# Altium schematic sheet Connector_0.SchDoc(Connector_0)
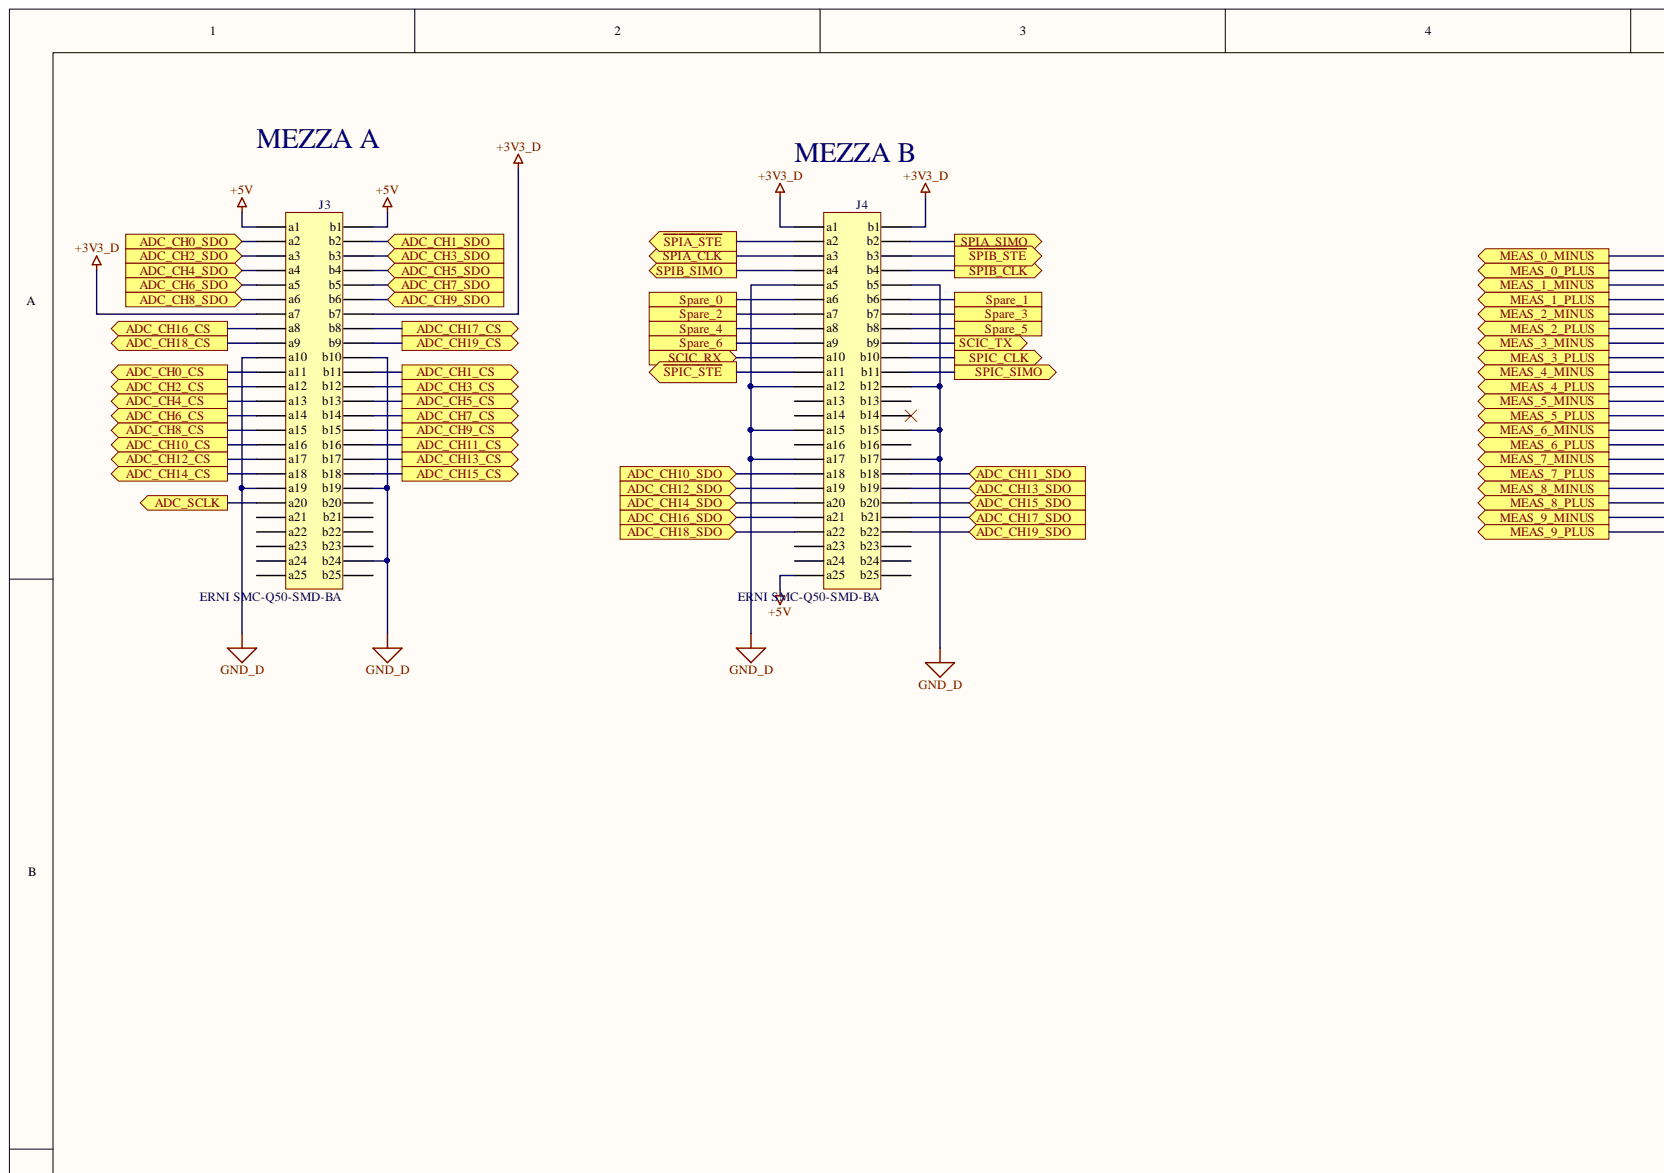
[diagram p0: Connector_0.SchDoc, top left]
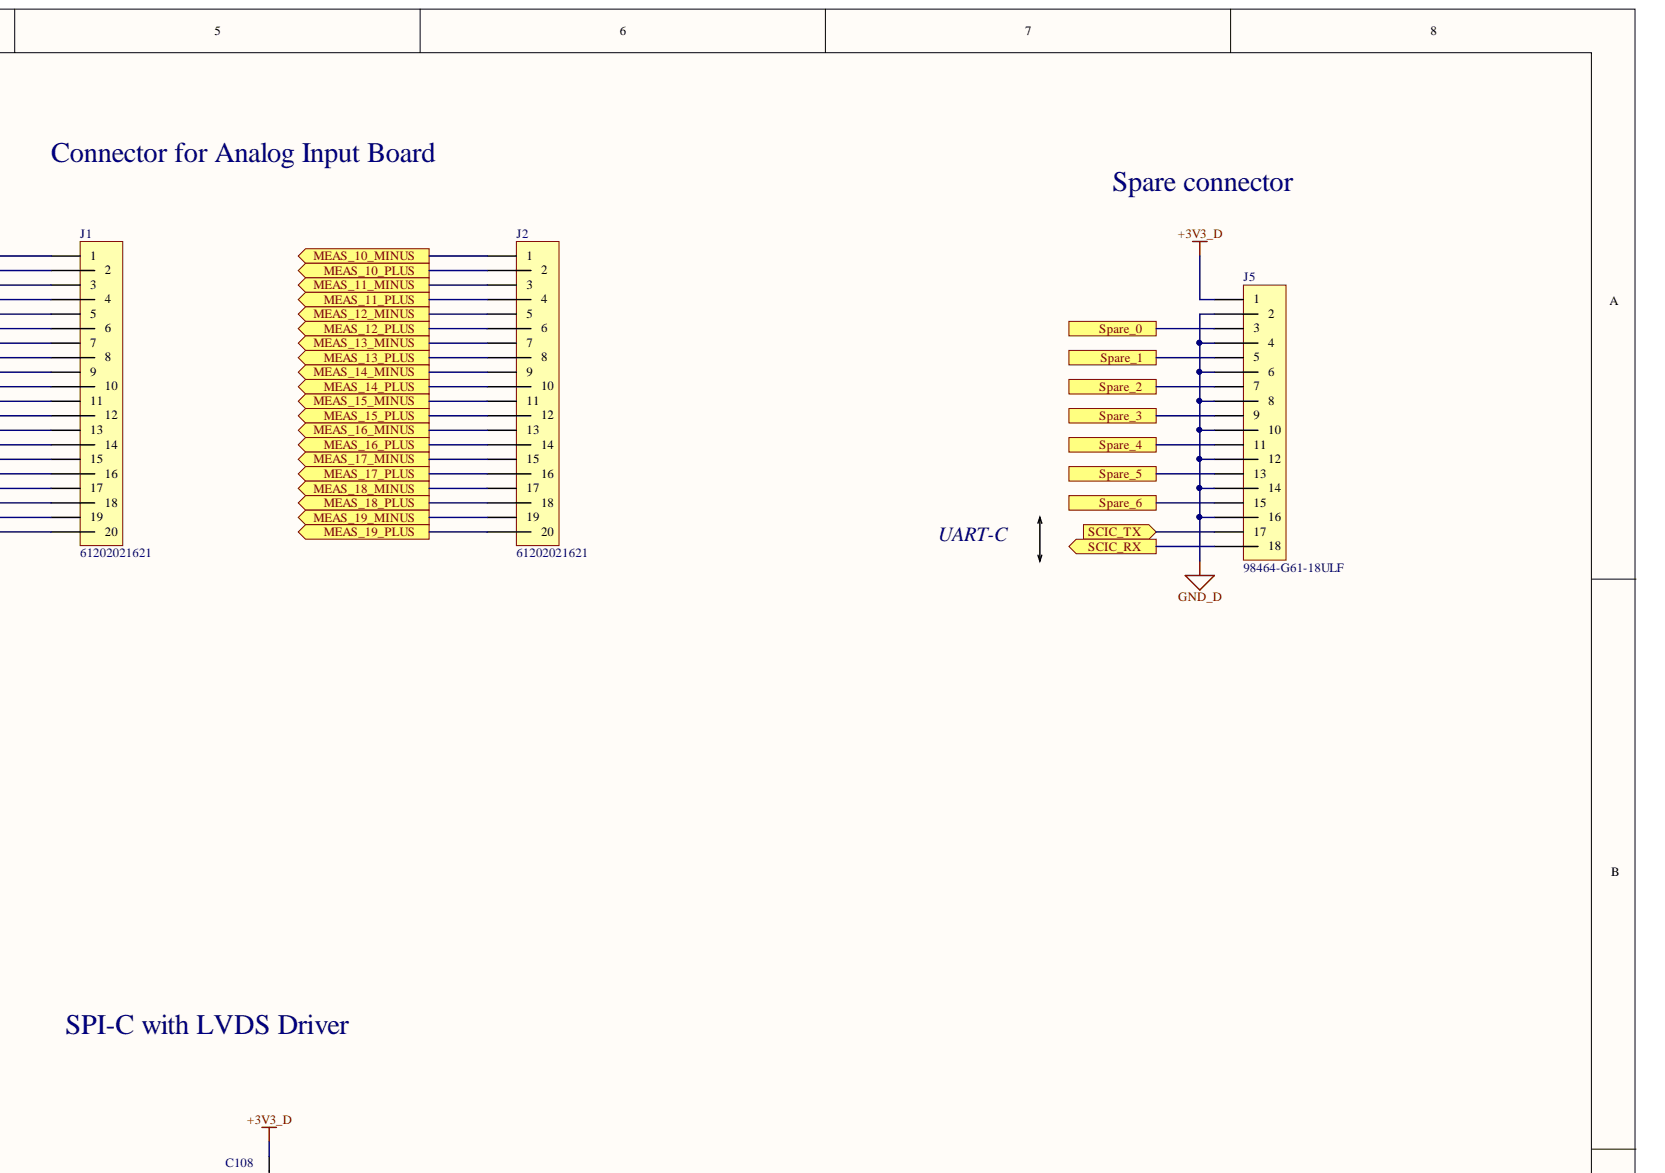
[diagram p1: Connector_0.SchDoc, top right]
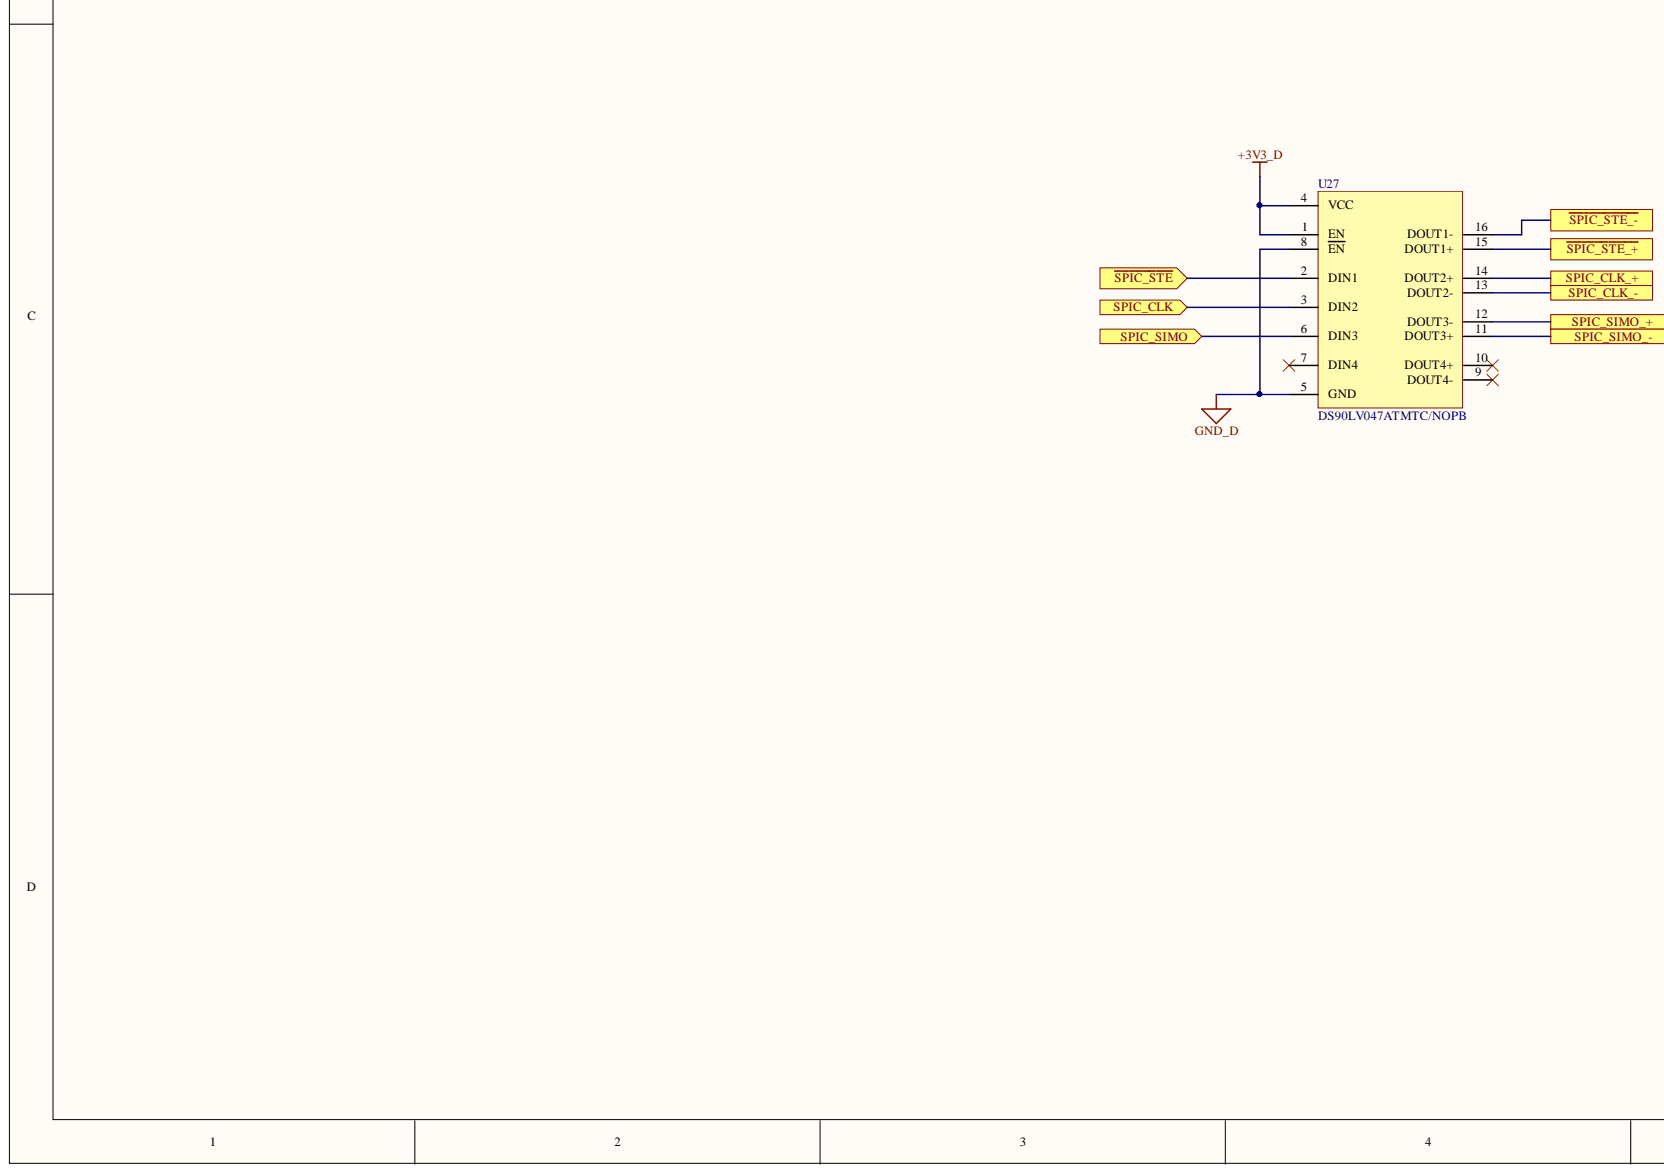
[diagram p2: Connector_0.SchDoc, bottom left]
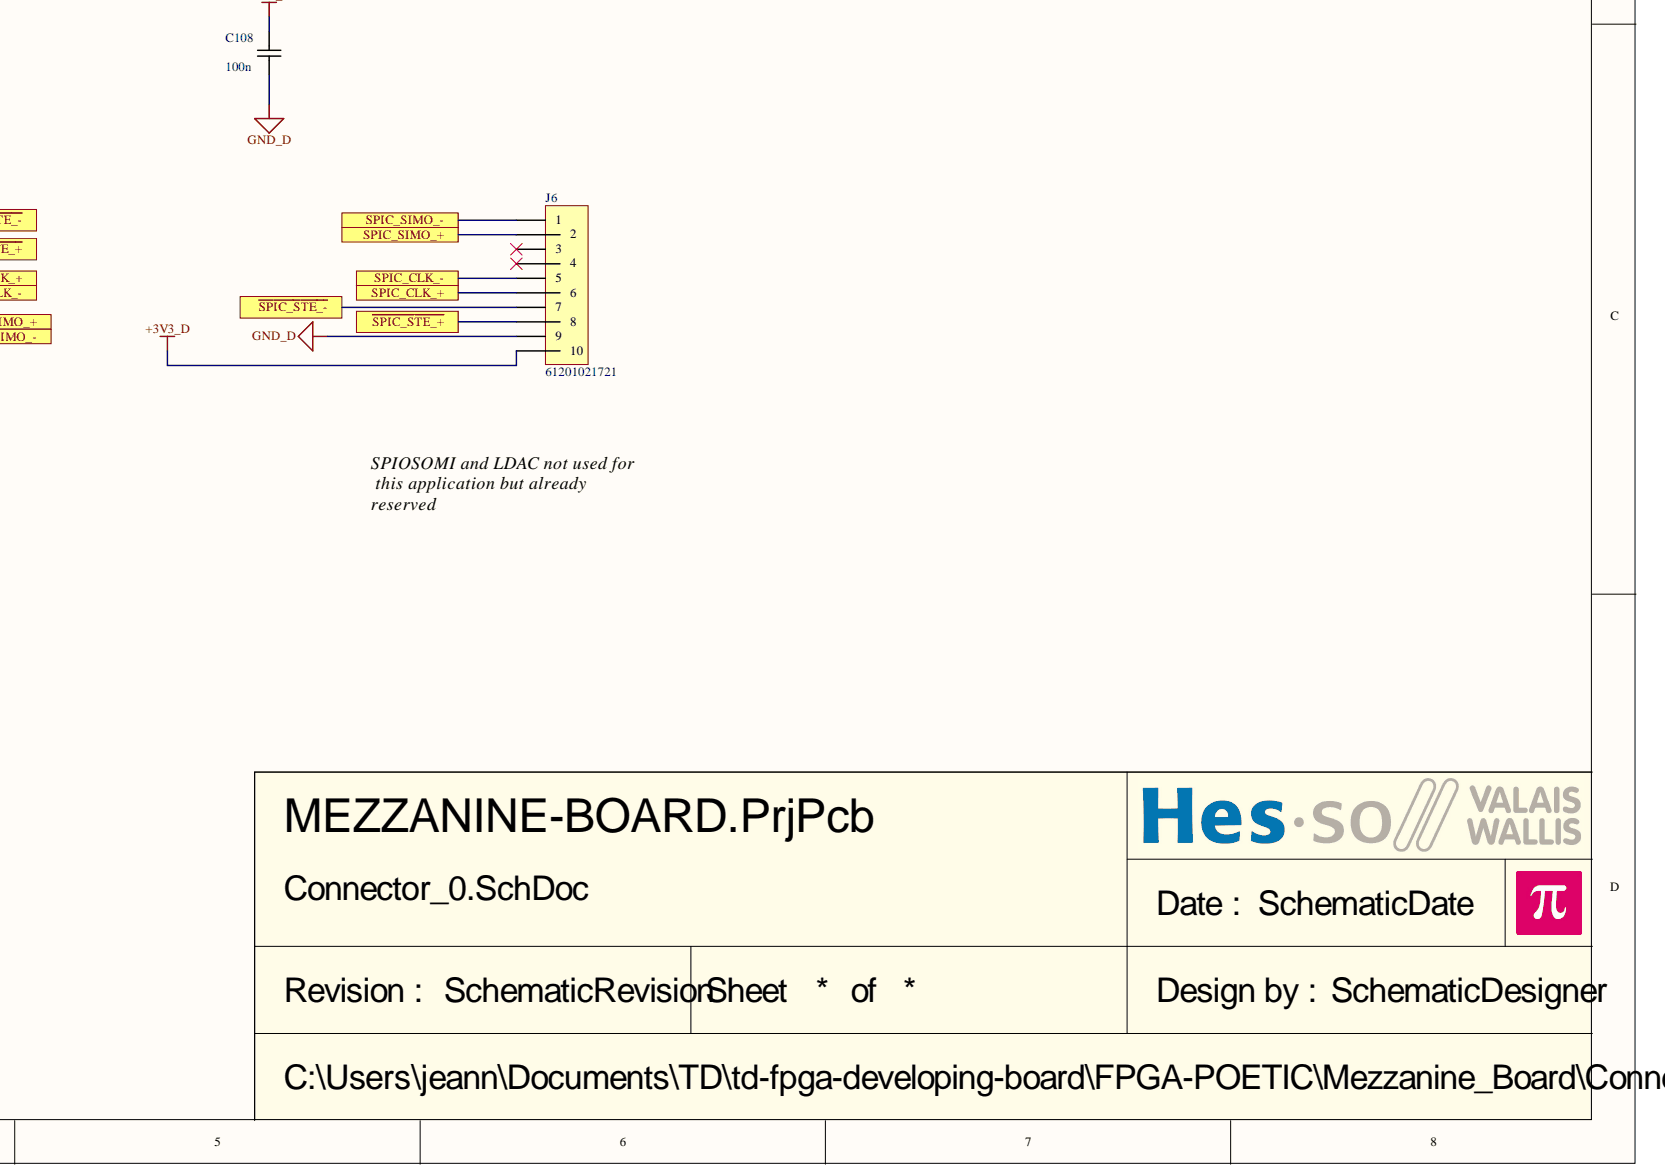
[diagram p3: Connector_0.SchDoc, bottom right]

## Parts
C108  100n  [CAPACITOR 2012 REFLOW]
J1  61202021621  [FlatCable2x10 2.54MM Straight TH]
J2  61202021621  [FlatCable2x10 2.54MM Straight TH]
J3  ERNI SMC-Q50-SMD-BA  [ERNI SMC-Q50-SMD-BA]
J4  ERNI SMC-Q50-SMD-BA  [ERNI SMC-Q50-SMD-BA]
J5  98464-G61-18ULF  [FlatCable2x9 2MM Right Angle TH FCI 98614-G61-18ULF]
J6  61201021721  [FlatCable2x5 2.54MM RIGHT ANGLE TH]
U27  DS90LV047ATMTC/NOPB  [TSSOP16 P0.65 C5.0x4.5 H1.1]

## Ports
ADC_CH0_CS, ADC_CH0_SDO, ADC_CH1_CS, ADC_CH1_SDO, ADC_CH2_CS, ADC_CH2_SDO, ADC_CH3_CS, ADC_CH3_SDO, ADC_CH4_CS, ADC_CH4_SDO, ADC_CH5_CS, ADC_CH5_SDO, ADC_CH6_CS, ADC_CH6_SDO, ADC_CH7_CS, ADC_CH7_SDO, ADC_CH8_CS, ADC_CH8_SDO, ADC_CH9_CS, ADC_CH9_SDO, ADC_CH10_CS, ADC_CH10_SDO, ADC_CH11_CS, ADC_CH11_SDO, ADC_CH12_CS, ADC_CH12_SDO, ADC_CH13_CS, ADC_CH13_SDO, ADC_CH14_CS, ADC_CH14_SDO, ADC_CH15_CS, ADC_CH15_SDO, ADC_CH16_CS, ADC_CH16_SDO, ADC_CH17_CS, ADC_CH17_SDO, ADC_CH18_CS, ADC_CH18_SDO, ADC_CH19_CS, ADC_CH19_SDO, ADC_SCLK, MEAS_0_MINUS, MEAS_0_PLUS, MEAS_1_MINUS, MEAS_1_PLUS, MEAS_2_MINUS, MEAS_2_PLUS, MEAS_3_MINUS, MEAS_3_PLUS, MEAS_4_MINUS, MEAS_4_PLUS, MEAS_5_MINUS, MEAS_5_PLUS, MEAS_6_MINUS, MEAS_6_PLUS, MEAS_7_MINUS, MEAS_7_PLUS, MEAS_8_MINUS, MEAS_8_PLUS, MEAS_9_MINUS, MEAS_9_PLUS, MEAS_10_MINUS, MEAS_10_PLUS, MEAS_11_MINUS, MEAS_11_PLUS, MEAS_12_MINUS, MEAS_12_PLUS, MEAS_13_MINUS, MEAS_13_PLUS, MEAS_14_MINUS, MEAS_14_PLUS, MEAS_15_MINUS, MEAS_15_PLUS, MEAS_16_MINUS, MEAS_16_PLUS, MEAS_17_MINUS, MEAS_17_PLUS, MEAS_18_MINUS, MEAS_18_PLUS, MEAS_19_MINUS, MEAS_19_PLUS, SCIC_RX, SCIC_TX, Spare_0, Spare_1, Spare_2, Spare_3, Spare_4, Spare_5, Spare_6, SPIA_CLK, SPIA_SIMO, SPIB_CLK, SPIB_SIMO, SPIC_CLK, SPIC_CLK_+, SPIC_CLK_-, SPIC_SIMO, SPIC_SIMO_+, SPIC_SIMO_-, ~{SPIA_STE}, ~{SPIB_STE}, ~{SPIC_STE_+}, ~{SPIC_STE_-}, ~{SPIC_STE}

## Nets
+3V3_D: C108.2 J3.a7 J3.b7 J4.a1 J4.b1 J5.1 J6.10 U27.1(EN) U27.4(VCC)
+5V: J3.a1 J3.b1 J4.a25
GND_D: C108.1 J3.a10 J3.a19 J3.b10 J3.b19 J3.b24 J4.a5 J4.a12 J4.a15 J4.a17 J4.b5 J4.b12 J4.b15 J4.b17 J5.2 J5.4 J5.6 J5.8 J5.10 J5.12 J5.14 J5.16 J6.9 U27.5(GND) U27.8(EN)
~{SPIA_STE}: J4.a2 ; port ~{SPIA_STE}
~{SPIB_STE}: J4.b3 ; port ~{SPIB_STE}
~{SPIC_STE}: J4.a11 U27.2(DIN1) ; port ~{SPIC_STE}
ADC_CH0_CS: J3.a11 ; port ADC_CH0_CS
ADC_CH0_SDO: J3.a2 ; port ADC_CH0_SDO
ADC_CH1_CS: J3.b11 ; port ADC_CH1_CS
ADC_CH1_SDO: J3.b2 ; port ADC_CH1_SDO
ADC_CH2_CS: J3.a12 ; port ADC_CH2_CS
ADC_CH2_SDO: J3.a3 ; port ADC_CH2_SDO
ADC_CH3_CS: J3.b12 ; port ADC_CH3_CS
ADC_CH3_SDO: J3.b3 ; port ADC_CH3_SDO
ADC_CH4_CS: J3.a13 ; port ADC_CH4_CS
ADC_CH4_SDO: J3.a4 ; port ADC_CH4_SDO
ADC_CH5_CS: J3.b13 ; port ADC_CH5_CS
ADC_CH5_SDO: J3.b4 ; port ADC_CH5_SDO
ADC_CH6_CS: J3.a14 ; port ADC_CH6_CS
ADC_CH6_SDO: J3.a5 ; port ADC_CH6_SDO
ADC_CH7_CS: J3.b14 ; port ADC_CH7_CS
ADC_CH7_SDO: J3.b5 ; port ADC_CH7_SDO
ADC_CH8_CS: J3.a15 ; port ADC_CH8_CS
ADC_CH8_SDO: J3.a6 ; port ADC_CH8_SDO
ADC_CH9_CS: J3.b15 ; port ADC_CH9_CS
ADC_CH9_SDO: J3.b6 ; port ADC_CH9_SDO
ADC_CH10_CS: J3.a16 ; port ADC_CH10_CS
ADC_CH10_SDO: J4.a18 ; port ADC_CH10_SDO
ADC_CH11_CS: J3.b16 ; port ADC_CH11_CS
ADC_CH11_SDO: J4.b18 ; port ADC_CH11_SDO
ADC_CH12_CS: J3.a17 ; port ADC_CH12_CS
ADC_CH12_SDO: J4.a19 ; port ADC_CH12_SDO
ADC_CH13_CS: J3.b17 ; port ADC_CH13_CS
ADC_CH13_SDO: J4.b19 ; port ADC_CH13_SDO
ADC_CH14_CS: J3.a18 ; port ADC_CH14_CS
ADC_CH14_SDO: J4.a20 ; port ADC_CH14_SDO
ADC_CH15_CS: J3.b18 ; port ADC_CH15_CS
ADC_CH15_SDO: J4.b20 ; port ADC_CH15_SDO
ADC_CH16_CS: J3.a8 ; port ADC_CH16_CS
ADC_CH16_SDO: J4.a21 ; port ADC_CH16_SDO
ADC_CH17_CS: J3.b8 ; port ADC_CH17_CS
ADC_CH17_SDO: J4.b21 ; port ADC_CH17_SDO
ADC_CH18_CS: J3.a9 ; port ADC_CH18_CS
ADC_CH18_SDO: J4.a22 ; port ADC_CH18_SDO
ADC_CH19_CS: J3.b9 ; port ADC_CH19_CS
ADC_CH19_SDO: J4.b22 ; port ADC_CH19_SDO
ADC_SCLK: J3.a20 ; port ADC_SCLK
MEAS_0_MINUS: J1.1 ; port MEAS_0_MINUS
MEAS_0_PLUS: J1.2 ; port MEAS_0_PLUS
MEAS_1_MINUS: J1.3 ; port MEAS_1_MINUS
MEAS_1_PLUS: J1.4 ; port MEAS_1_PLUS
MEAS_2_MINUS: J1.5 ; port MEAS_2_MINUS
MEAS_2_PLUS: J1.6 ; port MEAS_2_PLUS
MEAS_3_MINUS: J1.7 ; port MEAS_3_MINUS
MEAS_3_PLUS: J1.8 ; port MEAS_3_PLUS
MEAS_4_MINUS: J1.9 ; port MEAS_4_MINUS
MEAS_4_PLUS: J1.10 ; port MEAS_4_PLUS
MEAS_5_MINUS: J1.11 ; port MEAS_5_MINUS
MEAS_5_PLUS: J1.12 ; port MEAS_5_PLUS
MEAS_6_MINUS: J1.13 ; port MEAS_6_MINUS
MEAS_6_PLUS: J1.14 ; port MEAS_6_PLUS
MEAS_7_MINUS: J1.15 ; port MEAS_7_MINUS
MEAS_7_PLUS: J1.16 ; port MEAS_7_PLUS
MEAS_8_MINUS: J1.17 ; port MEAS_8_MINUS
MEAS_8_PLUS: J1.18 ; port MEAS_8_PLUS
MEAS_9_MINUS: J1.19 ; port MEAS_9_MINUS
MEAS_9_PLUS: J1.20 ; port MEAS_9_PLUS
MEAS_10_MINUS: J2.1 ; port MEAS_10_MINUS
MEAS_10_PLUS: J2.2 ; port MEAS_10_PLUS
MEAS_11_MINUS: J2.3 ; port MEAS_11_MINUS
MEAS_11_PLUS: J2.4 ; port MEAS_11_PLUS
MEAS_12_MINUS: J2.5 ; port MEAS_12_MINUS
MEAS_12_PLUS: J2.6 ; port MEAS_12_PLUS
MEAS_13_MINUS: J2.7 ; port MEAS_13_MINUS
MEAS_13_PLUS: J2.8 ; port MEAS_13_PLUS
MEAS_14_MINUS: J2.9 ; port MEAS_14_MINUS
MEAS_14_PLUS: J2.10 ; port MEAS_14_PLUS
MEAS_15_MINUS: J2.11 ; port MEAS_15_MINUS
MEAS_15_PLUS: J2.12 ; port MEAS_15_PLUS
MEAS_16_MINUS: J2.13 ; port MEAS_16_MINUS
MEAS_16_PLUS: J2.14 ; port MEAS_16_PLUS
MEAS_17_MINUS: J2.15 ; port MEAS_17_MINUS
MEAS_17_PLUS: J2.16 ; port MEAS_17_PLUS
MEAS_18_MINUS: J2.17 ; port MEAS_18_MINUS
MEAS_18_PLUS: J2.18 ; port MEAS_18_PLUS
MEAS_19_MINUS: J2.19 ; port MEAS_19_MINUS
MEAS_19_PLUS: J2.20 ; port MEAS_19_PLUS
SCIC_RX: J4.a10 J5.18 ; port SCIC_RX
SCIC_TX: J4.b9 J5.17 ; port SCIC_TX
Spare_0: J4.a6 J5.3 ; port Spare_0
Spare_1: J4.b6 J5.5 ; port Spare_1
Spare_2: J4.a7 J5.7 ; port Spare_2
Spare_3: J4.b7 J5.9 ; port Spare_3
Spare_4: J4.a8 J5.11 ; port Spare_4
Spare_5: J4.b8 J5.13 ; port Spare_5
Spare_6: J4.a9 J5.15 ; port Spare_6
SPIA_CLK: J4.a3 ; port SPIA_CLK
SPIA_SIMO: J4.b2 ; port SPIA_SIMO
SPIB_CLK: J4.b4 ; port SPIB_CLK
SPIB_SIMO: J4.a4 ; port SPIB_SIMO
SPIC_CLK: J4.b10 U27.3(DIN2) ; port SPIC_CLK
SPIC_SIMO: J4.b11 U27.6(DIN3) ; port SPIC_SIMO
NetJ6_1: J6.1 U27.11(DOUT3+)
NetJ6_2: J6.2 U27.12(DOUT3-)
NetJ6_5: J6.5 U27.13(DOUT2-)
NetJ6_6: J6.6 U27.14(DOUT2+)
NetJ6_7: J6.7 U27.16(DOUT1-)
NetJ6_8: J6.8 U27.15(DOUT1+)
N00217:

Not connected: J3 a21 a22 a23 a24 a25 b20 b21 b22 b23 b25; J4 a13 a14 a16 a23 a24 b13 b14 b16 b23 b24 b25; J6 3 4; U27 7(DIN4) 9(DOUT4-) 10(DOUT4+)

## Library data of the parts
C108 = Capacitor: Capacitor X7R 10% 50V 2012(0805); Value: 100n; Library Name: Capacitor.IntLib; Component Kind: Standard
J1 J2 = FlatCable2x10: Manufacturer: Wurth Elektronik; Value: 61202021621; Mouser No.: 710-61202021621; Library Name: Connector.IntLib; Description: FlatCable2x10; Component Kind: Standard
J3 J4 = ERNI SMC-Q50-SMD-BA: Male 1.27 pitch 2x25 pin connector; Library Name: Connector.IntLib; Component Kind: Standard
J5 = FlatCable2x9: Manufacturer: Amphenol FCI; Value: 98464-G61-18ULF; Mouser No.: 649-98464-G61-18ULF; Library Name: Connector.IntLib; Description: FlatCable2x9; Component Kind: Standard
J6 = FlatCable2x5: Manufacturer: Wurth Elektronik; Value: 61201021721; Mouser No.: 710-61201021721; Library Name: Connector.IntLib; Description: FlatCable2x5; Component Kind: Standard
U27 = DS90LV047A: DS90LV047A 3-V LVDS Quad CMOS Differential Line Driver; Manufacturer: Texas Instruments; Value: DS90LV047ATMTC/NOPB; Mouser No.: 926-DS90LV047ATMTCNO; Library Name: IntegratedCircuit.IntLib; Component Kind: Standard
